# Altium schematic sheet MCU_LoRa.SchDoc(MCU_LoRa)
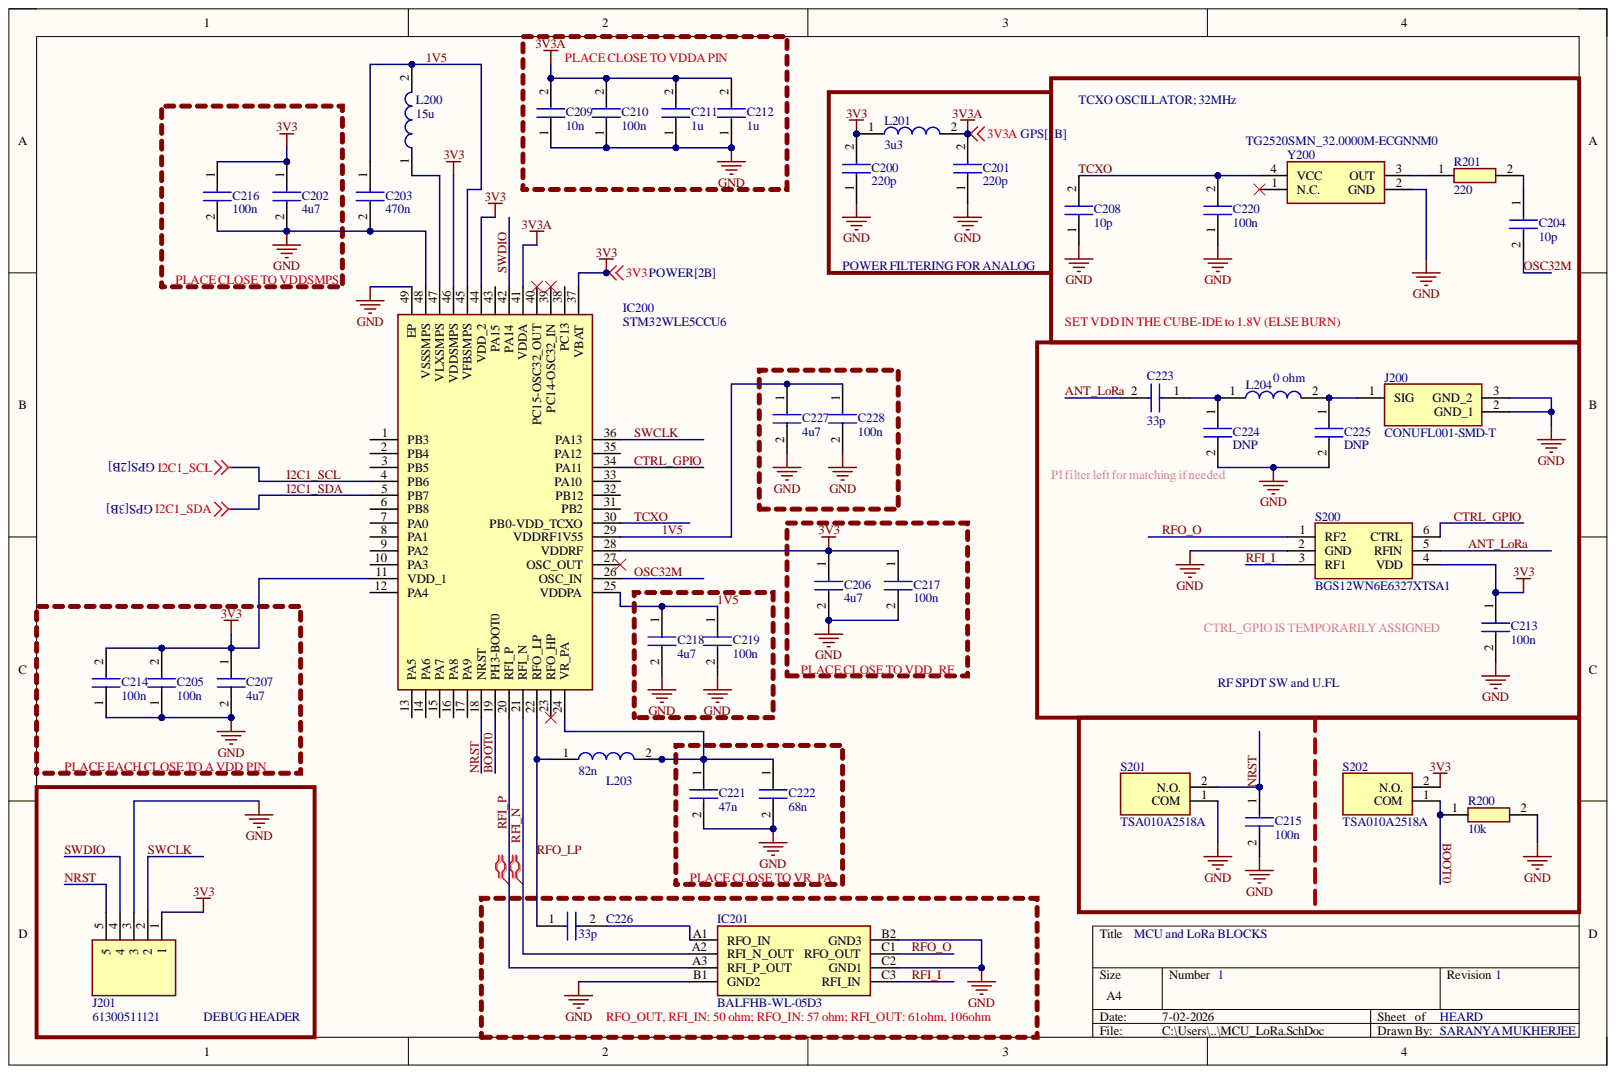
[diagram p0: MCU_LoRa.SchDoc]

## Parts
C200  220p  [CAPC0402X22N]
C201  220p  [CAPC0402X22N]
C202  4u7  [GRM033D70J224ME01D]
C203  470n  [CAPC0402X22N]
C204  10p  [CAPC0402X22N]
C205  100n  [CAPC0402X22N]
C206  4u7  [GRM033D70J224ME01D]
C207  4u7  [GRM033D70J224ME01D]
C208  10p  [CAPC0402X22N]
C209  10n  [CAPC0402X22N]
C210  100n  [CAPC0402X22N]
C211  1u  [CAPC0402X22N]
C212  1u  [CAPC0402X22N]
C213  100n  [CAPC0402X22N]
C214  100n  [CAPC0402X22N]
C215  100n  [CAPC0402X22N]
C216  100n  [CAPC0402X22N]
C217  100n  [CAPC0402X22N]
C218  4u7  [GRM033D70J224ME01D]
C219  100n  [CAPC0402X22N]
C220  100n  [CAPC0402X22N]
C221  47n  [CAPC0402X22N]
C222  68n  [CAPC0402X22N]
C223  33p  [CAPC0402X22N]
C224  DNP  [CAPC0402X22N]
C225  DNP  [CAPC0402X22N]
C226  33p  [CAPC0402X22N]
C227  4u7  [GRM033D70J224ME01D]
C228  100n  [CAPC0402X22N]
IC200  STM32WLE5CCU6  [QFN50P700X700X60-49N-D]
IC201  BALFHB-WL-05D3  [BALFHBWL05D3]
J200  CONUFL001-SMD-T  [CONUFL001SMDT]  (value not drawn)
J201  61300511121  [HDRV5W64P0X254_1X5_1270X254X854P]
L200  15u  [LQM18PN3R3MFCL]
L201  3u3  [LQM18PN3R3MFCL]
L203  82n  [LQW15DN100M00D]
L204  0 ohm  [CAPC0402X22N]
R200  10k  [RESC1005X40N]
R201  220  [RESC1005X40N]
S200  BGS12WN6E6327XTSA1  [BGS12WN6E6327XTSA1]
S201  TSA010A2518A  [TSA010A2518A]
S202  TSA010A2518A  [TSA010A2518A]
Y200  TG2520SMN_32.0000M-ECGNNM0  [TG2520SMN320000MECGNNM0]

## Nets
3V3: C200.2 C202.1 C205.2 C206.1 C207.1 C213.1 C214.2 C216.1 C217.1 IC200.11(VDD_1) IC200.28(VDDRF) IC200.37(VBAT) IC200.44(VDD_2) IC200.46(VDDSMPS) J201.1 L201.1 S200.4(VDD) S202.2
3V3A: C201.2 C209.2 C210.2 C211.2 C212.2 IC200.41(VDDA) L201.2
GND: C200.1 C201.1 C202.2 C203.2 C205.1 C206.2 C207.2 C208.1 C209.1 C210.1 C211.1 C212.1 C213.2 C214.1 C215.2 C216.2 C217.2 C218.2 C219.2 C220.1 C221.2 C222.2 C224.2 C225.2 C227.2 C228.2 IC200.48(VSSSMPS) IC200.49(EP) IC201.B1(GND2) IC201.B2(GND3) IC201.C2(GND1) J200.2 J200.3 J201.3 R200.2 S200.2(GND) S201.1 Y200.2(GND)
1V5: C203.1 C218.1 C219.1 C227.1 C228.1 IC200.25(VDDPA) IC200.29(VDDRF1V55) IC200.45(VFBSMPS) L200.2
ANT_LoRa: C223.2 S200.5(RFIN)
BOOT0: IC200.19(PH3-BOOT0) R200.1 S202.1
CTRL_GPIO: IC200.34(PA11) S200.6(CTRL)
I2C1_SCL: IC200.4(PB6)
I2C1_SDA: IC200.5(PB7)
NRST: C215.1 IC200.18(NRST) J201.5 S201.2
OSC32M: C204.2 IC200.26(OSC_IN)
RFI_I: IC201.C3(RFI_IN) S200.3(RF1)
RFI_N: IC200.21(RFI_N) IC201.A2(RFI_N_OUT)
RFI_P: IC200.20(RFI_P) IC201.A3(RFI_P_OUT)
RFO_LP: C226.1 IC200.22(RFO_LP) L203.1
RFO_O: IC201.C1(RFO_OUT) S200.1(RF2)
SWCLK: IC200.36(PA13) J201.2
SWDIO: IC200.42(PA14) J201.4
TCXO: C208.2 C220.2 IC200.30(PB0-VDD_TCXO) Y200.4(VCC)
NetC204_1: C204.1 R201.2
NetC221_1: C221.1 C222.1 IC200.24(VR_PA) L203.2
NetC223_1: C223.1 C224.1 L204.1
NetC225_1: C225.1 J200.1 L204.2
NetC226_2: C226.2 IC201.A1(RFO_IN)
NetIC200_47: IC200.47(VLXSMPS) L200.1
NetR201_1: R201.1 Y200.3(OUT)

Not connected: IC200 1(PB3) 2(PB4) 3(PB5) 6(PB8) 7(PA0) 8(PA1) 9(PA2) 10(PA3) 12(PA4) 13(PA5) 14(PA6) 15(PA7) 16(PA8) 17(PA9) 23(RFO_HP) 27(OSC_OUT) 31(PB2) 32(PB12) 33(PA10) 35(PA12) 38(PC13) 39(PC14-OSC32_IN) 40(PC15-OSC32_OUT) 43(PA15); Y200 1(N.C.)

## Library data of the parts
C200-C228 = C0402X7R0G101K020BC: Capacitor; Manufacturer_Name: TDK; Component Kind: Standard; Datasheet Link: https://product.tdk.com/system/files/dam/doc/product/capacitor/ceramic/mlcc/catalog/mlcc_commercial_general_en.pdf; Height: 0.22mm; Manufacturer_Part_Number: C0402X7R0G101K020BC
IC200 = STM32WLE5CCU6: Integrated Circuit; Manufacturer_Name: STMicroelectronics; Arrow Part Number: STM32WLE5CCU6; Arrow Price/Stock: https://www.arrow.com/en/products/stm32wle5ccu6/stmicroelectronics?utm_currency=USD&region=nac; Component Kind: Standard; Datasheet Link: https://www.st.com/resource/en/datasheet/stm32wle5c8.pdf; Height: 0.6mm; Manufacturer_Part_Number: STM32WLE5CCU6; Mouser Part Number: 511-STM32WLE5CCU6; Mouser Price/Stock: https://www.mouser.co.uk/ProductDetail/STMicroelectronics/STM32WLE5CCU6?qs=26oI9%252Bq63sLM90C6xjPI1g%3D%3D
IC201 = BALFHB-WL-05D3: Integrated Circuit; Manufacturer_Name: STMicroelectronics; Arrow Part Number: BALFHB-WL-05D3; Arrow Price/Stock: https://www.arrow.com/en/products/balfhb-wl-05d3/stmicroelectronics.html?utm_currency=USD&region=nac; Component Kind: Standard; Datasheet Link: https://www.st.com/resource/en/datasheet/balfhb-wl-05d3.pdf; Height: 0.68mm; Manufacturer_Part_Number: BALFHB-WL-05D3; Mouser Part Number: 511-BALFHB-WL-05D3; Mouser Price/Stock: https://www.mouser.co.uk/ProductDetail/STMicroelectronics/BALFHB-WL-05D3?qs=T%252BzbugeAwjgyeRVodYmoDw%3D%3D
J200 = CONUFL001-SMD-T: Connector; Manufacturer_Name: TE Connectivity; Arrow Part Number: CONUFL001-SMD-T; Arrow Price/Stock: https://www.arrow.com/en/products/conufl001-smd-t/te-connectivity?utm_currency=USD&region=nac; Component Kind: Standard; Datasheet Link: https://eu.mouser.com/datasheet/2/418/9/ENG_DS_CONUFL001_SMD_T_B-3238717.pdf; Height: 1.3mm; Manufacturer_Part_Number: CONUFL001-SMD-T; Mouser Part Number: N/A; Mouser Price/Stock: https://www.mouser.co.uk/ProductDetail/TE-Connectivity/CONUFL001-SMD-T?qs=3Rah4i%252BhyCHmRzMH%252BkLkPQ%3D%3D
J201 = 61300511121: Connector; Manufacturer_Name: Wurth Elektronik; Component Kind: Standard; Datasheet Link: https://www.we-online.com/catalog/datasheet/61300511121.pdf; Height: 8.54mm; Manufacturer_Part_Number: 61300511121; Mouser Part Number: 710-61300511121; Mouser Price/Stock: https://www.mouser.co.uk/ProductDetail/Wurth-Elektronik/61300511121?qs=PhR8RmCirEZCsCRHq%252BvfRQ%3D%3D
L200 L201 = LQM18PN3R3MFCL: Inductor; Manufacturer_Name: Murata Electronics; Component Kind: Standard; Datasheet Link: https://pim.murata.com/pim/details/?productCategoryId=inductor&partNum=LQM18PN3R3MFC%23; Height: 0.95mm; Manufacturer_Part_Number: LQM18PN3R3MFCL
L203 L204 = LQW15DN150M00D: Inductor; Manufacturer_Name: Murata Electronics; Component Kind: Standard; Datasheet Link: https://www.digikey.com/en/products/detail/murata-electronics/LQW15DN150M00D/9559544; Height: 0.7mm; Manufacturer_Part_Number: LQW15DN150M00D; Mouser Part Number: 81-LQW15DN150M00D; Mouser Price/Stock: https://www.mouser.co.uk/ProductDetail/Murata-Electronics/LQW15DN150M00D?qs=55YtniHzbhAjiaw66ArnFg%3D%3D
R200 R201 = RC0402JR-070RL: Resistor; Manufacturer_Name: Yageo Group; Arrow Part Number: RC0402JR-070RL; Arrow Price/Stock: https://www.arrow.com/en/products/rc0402jr-070rl/yageo.html?utm_currency=USD&region=europe; Component Kind: Standard; Datasheet Link: https://www.yageo.com/upload/media/product/products/datasheet/rchip/PYu-RC_Group_51_RoHS_L_12.pdf; Height: 0.4mm; Manufacturer_Part_Number: RC0402JR-070RL; Mouser Part Number: 603-RC0402JR-070RL; Mouser Price/Stock: https://www.mouser.co.uk/ProductDetail/YAGEO/RC0402JR-070RL?qs=5Bml4Cp94iv7ykKkzPrwpQ%3D%3D
S200 = BGS12WN6E6327XTSA1: Switch; Manufacturer_Name: Infineon; Arrow Part Number: BGS12WN6E6327XTSA1; Arrow Price/Stock: https://www.arrow.com/en/products/bgs12wn6e6327xtsa1/infineon-technologies-ag.html?utm_currency=USD&region=nac; Component Kind: Standard; Datasheet Link: https://www.infineon.com/dgdl/Infineon-BGS12WN6-DataSheet-v01_00-EN.pdf?fileId=5546d4626b2d8e69016b89d2b3334727; Height: 0.4mm; Manufacturer_Part_Number: BGS12WN6E6327XTSA1; Mouser Part Number: 726-BGS12WN6E6327XTS; Mouser Price/Stock: https://www.mouser.co.uk/ProductDetail/Infineon-Technologies/BGS12WN6E6327XTSA1?qs=unwgFEO1A6uim3e4emYLzg%3D%3D
S201 S202 = TSA010A2518A: Switch; Manufacturer_Name: BZCN; Component Kind: Standard; Datasheet Link: https://robu.in/wp-content/uploads/2024/10/C2888945.pdf; Height: 2.75mm; Manufacturer_Part_Number: TSA010A2518A
Y200 = TG2520SMN_32.0000M-ECGNNM0: Crystal or Oscillator; Manufacturer_Name: Epson Timing; Manufacturer_Part_Number: TG2520SMN 32.0000M-ECGNNM0; Arrow Part Number: TG2520SMN 32.0000M-ECGNNM0; Arrow Price/Stock: https://www.arrow.com/en/products/tg2520smn-32.0000m-ecgnnm0/epson-electronics-america?utm_currency=USD&region=nac; Component Kind: Standard; Datasheet Link: https://support.epson.biz/td/api/doc_check.php?dl=brief_TG2520SMN&lang=en; Height: 0.8mm
